# Altium schematic sheet TopLevel.SchDoc
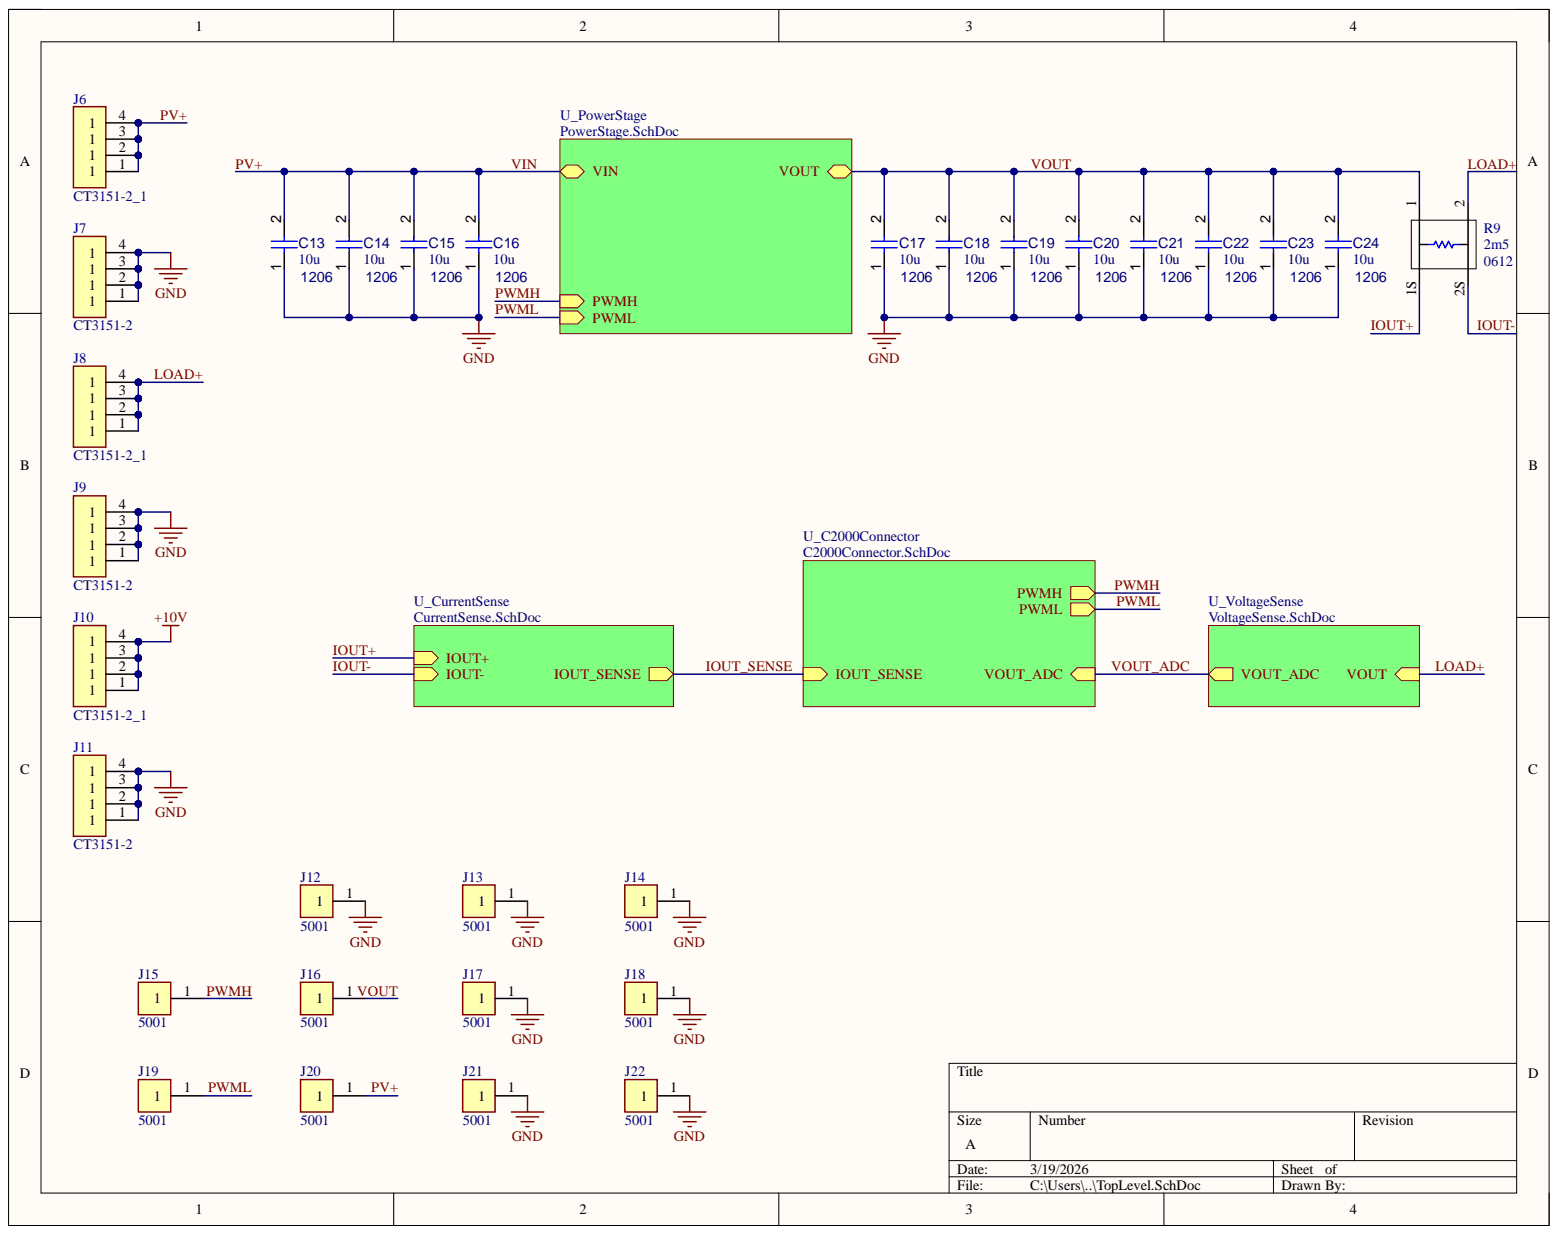
[diagram p0: TopLevel.SchDoc]

## Parts
C13  1206  value 10u  [CAPC3216X180X50NL20T25]
C14  1206  value 10u  [CAPC3216X180X50NL20T25]
C15  1206  value 10u  [CAPC3216X180X50NL20T25]
C16  1206  value 10u  [CAPC3216X180X50NL20T25]
C17  1206  value 10u  [CAPC3216X180X50NL20T25]
C18  1206  value 10u  [CAPC3216X180X50NL20T25]
C19  1206  value 10u  [CAPC3216X180X50NL20T25]
C20  1206  value 10u  [CAPC3216X180X50NL20T25]
C21  1206  value 10u  [CAPC3216X180X50NL20T25]
C22  1206  value 10u  [CAPC3216X180X50NL20T25]
C23  1206  value 10u  [CAPC3216X180X50NL20T25]
C24  1206  value 10u  [CAPC3216X180X50NL20T25]
J6  CT3151-2_1  [CALTEST_CT3151-2]
J7  CT3151-2  [CT3151-0]
J8  CT3151-2_1  [CALTEST_CT3151-2]
J9  CT3151-2  [CT3151-0]
J10  CT3151-2_1  [CALTEST_CT3151-2]
J11  CT3151-2  [CT3151-0]
J12  5001  [FP-5001-MFG]
J13  5001  [FP-5001-MFG]
J14  5001  [FP-5001-MFG]
J15  5001  [FP-5001-MFG]
J16  5001  [FP-5001-MFG]
J17  5001  [FP-5001-MFG]
J18  5001  [FP-5001-MFG]
J19  5001  [FP-5001-MFG]
J20  5001  [FP-5001-MFG]
J21  5001  [FP-5001-MFG]
J22  5001  [FP-5001-MFG]
R9  0612  value 2m5  [OHMITE_FC4L32]

## Nets
+10V: J10.1 J10.2 J10.3 J10.4
GND: C13.1 C14.1 C15.1 C16.1 C17.1 C18.1 C19.1 C20.1 C21.1 C22.1 C23.1 C24.1 J7.1 J7.2 J7.3 J7.4 J9.1 J9.2 J9.3 J9.4 J11.1 J11.2 J11.3 J11.4 J12.1 J13.1 J14.1 J17.1 J18.1 J21.1 J22.1
IOUT+: R9.1S
IOUT-: R9.2S
LOAD+: J8.1 J8.2 J8.3 J8.4 R9.2
PV+: C13.2 C14.2 C15.2 C16.2 J6.1 J6.2 J6.3 J6.4 J20.1
PWMH: J15.1
PWML: J19.1
VOUT: C17.2 C18.2 C19.2 C20.2 C21.2 C22.2 C23.2 C24.2 J16.1 R9.1
IOUT_SENSE:
VOUT_ADC:

## Library data of the parts
C13-C24 = CMP-001-00016-6: Chip Capacitor, 10 uF, +/- 10%, 16 V, 1206 (3216 Metric); Capacitor Type: Ceramic; Voltage Rating: 16V; Case/Package: 1206; Component Kind: Standard; Max Operating Temperature: 125°C; Min Operating Temperature: -55°C; Mounting Technology: SM; Pins: 2; RoHS Compliant: True; Tolerance: 10%; Library Name: Ishaan Gupta
J6 J8 J10 = CMP-004-000009-1: Banana Jack Connector Standard Banana Solder Red; MF: {'manufacturer': u'Cal Test'}; Availability: Unavailable; Component Kind: Standard; Component Type: Standard; Design Item ID: CT3151-2_1; MP: CT3151-2; Package: None; Pin Count: 4; Price: None; Source: PV Buck v2.SCHLIB; Library Name: Ishaan Gupta
J7 J9 J11 = CMP-004-000008-1: Banana Jack Connector Standard Banana Solder Red; MF: {'manufacturer': u'Cal Test'}; Availability: Unavailable; Component Kind: Standard; Component Type: Standard; Design Item ID: CT3151-2; MP: CT3151-2; Package: None; Pin Count: 4; Price: None; Source: PV Buck v2.SCHLIB; Library Name: Ishaan Gupta
J12-J22 = CMP-010-000010-1: PC TEST POINT MINIATURE BLACK; Manufacturer: Keystone Electronics; Body Material: Bronze; Hole Diameter: 1.6mm; Inside Diameter: 1.27mm; Insulation: Insulated; Number of Contacts: 1; Outside Diameter: 2.54mm; Plating: Silver; Color: Black; Component Kind: Standard; Component Type: Standard; Contact Material: Bronze; Contact Plating: Silver; Design Item ID: CMP-2000-05181-2; Diameter: 2.54mm; Flammability Rating: UL94 V-0; Height: 0.3inch; Housing Color: Black; Insulation Material: Nylon; Lead Free: Lead Free; Length: 7.62mm; Manufacturer Part Number: 5001; Material: Nylon; Mount: Through Hole; Number of Ports: 1; Orientation: Straight; Package Quantity: 100; Packaging: Bulk; Pin Count: 1; REACH SVHC: No SVHC; RoHS Compliant: Yes; Series: THM; Source: PV Buck v2.SCHLIB; Termination: Through Hole; Library Name: Ishaan Gupta
R9 = CMP-009-000017-1: 2m, 0612, 2%, 1W; Current: 45A; Mfg: Ohmite; MfgPN: FC4L32R002GER; TempOperatingMax: 155; TempOperatingMin: -55; Voltage: 0.045V; Component Kind: Standard; Component Type: Standard; Design Item ID: FC4L32R002GER; Material: Metal Foil; Pin Count: 4; Power: 1W; RoHS: True; SMD: True; Source: PV Buck v2.SCHLIB; Tolerance: 2%; Library Name: Ishaan Gupta
